# Altium schematic sheet Power Supply und DC-DC Converter.SchDoc
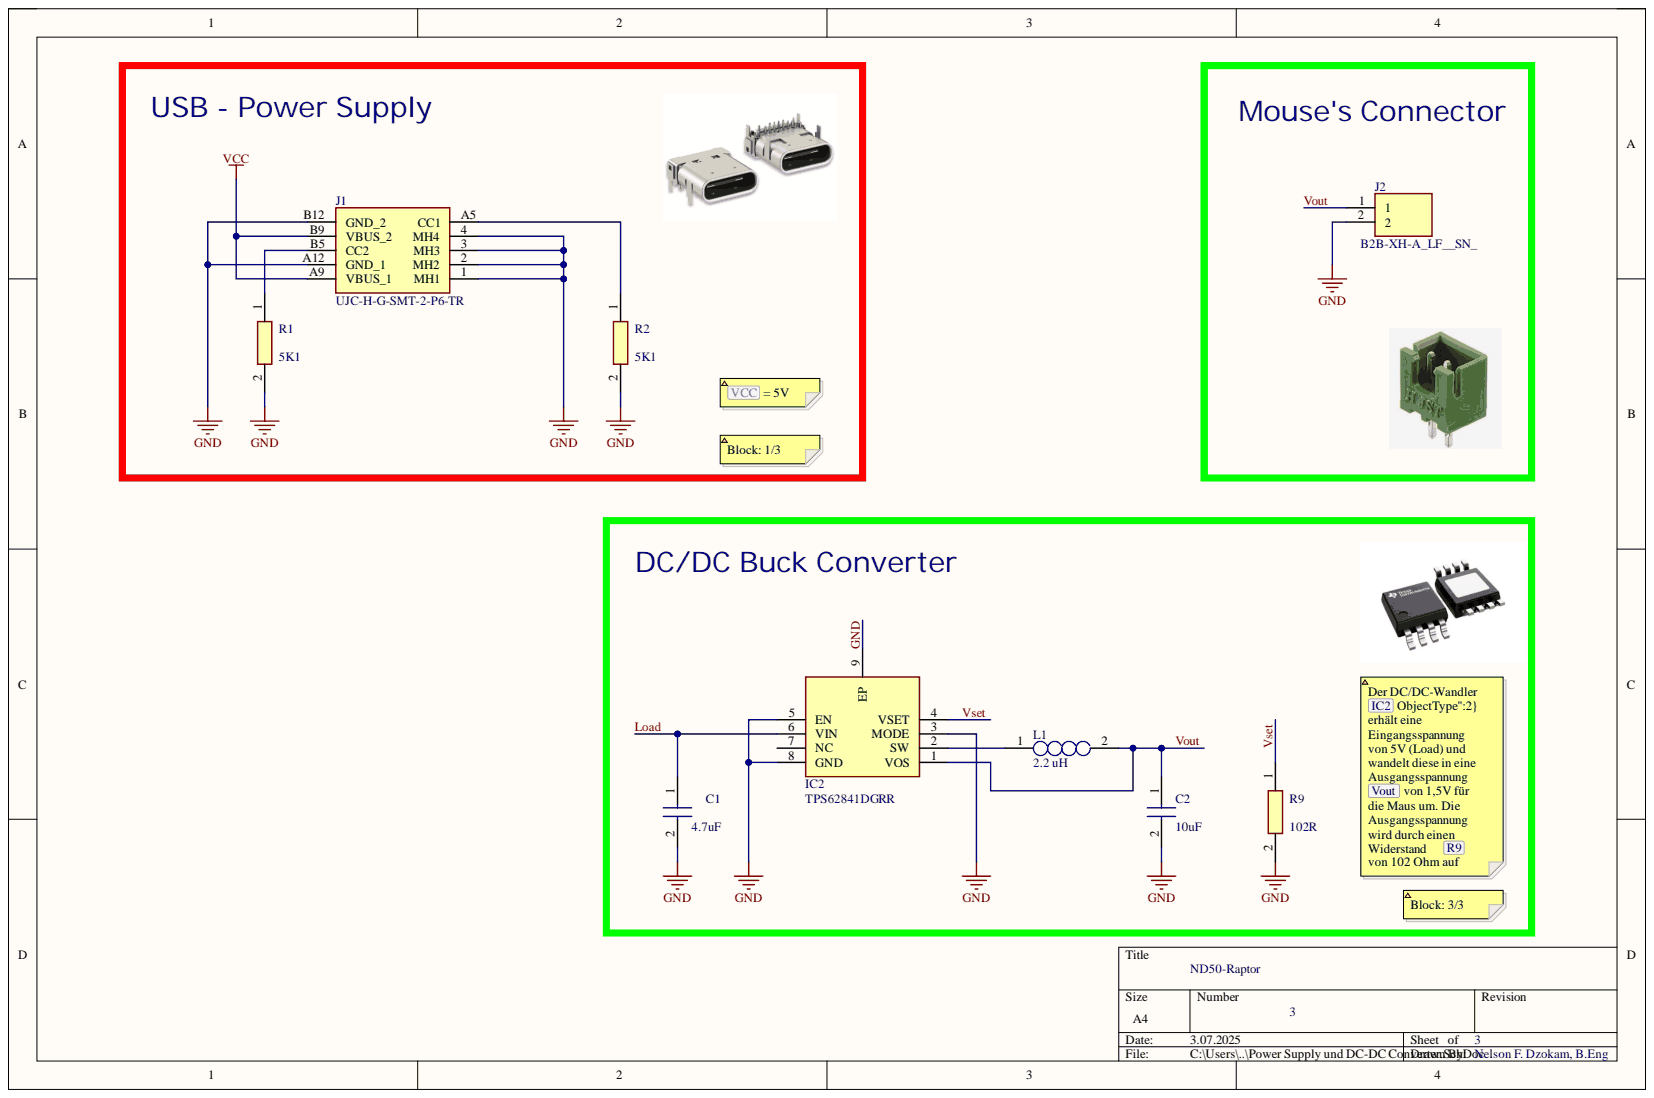
[diagram p0: Power Supply und DC-DC Converter.SchDoc]

## Parts
C1  4.7uF  [CAPC2012X145N]
C2  10uF  [C0805]
IC2  TPS62841DGRR  [SOP65P490X110-9N]
J1  UJC-H-G-SMT-2-P6-TR  [UJCHGSMT2P6TR]
J2  B2B-XH-A_LF__SN_  [SHDR2W64P0X250_1X2_740X575X700P]
L1  2.2 uH  [1286ASH1R0MP2]
R1  5K1  [RESC2012X60N]
R2  5K1  [RESC2012X60N]
R9  102R  [ERJ6ENF1000V]

## Nets
VCC: J1.A9(VBUS_1) J1.B9(VBUS_2)
GND: C1.2 C2.2 IC2.3(MODE) IC2.5(EN) IC2.8(GND) IC2.9(EP) J1.1(MH1) J1.2(MH2) J1.3(MH3) J1.4(MH4) J1.A12(GND_1) J1.B12(GND_2) J2.2 R1.2 R2.2 R9.2
Load: C1.1 IC2.6(VIN)
Vout: C2.1 IC2.1(VOS) J2.1 L1.2
Vset: IC2.4(VSET) R9.1
NetIC2_2: IC2.2(SW) L1.1
NetJ1_A5: J1.A5(CC1) R2.1
NetJ1_B5: J1.B5(CC2) R1.1

Not connected: IC2 7(NC)

## Library data of the parts
C1 = 885012107009: Capacitor               885012107009; Manufacturer_Name: Wurth Elektronik; Component Kind: Standard; Datasheet Link: https://katalog.we-online.com/pbs/datasheet/885012107009.pdf; Height: 1.45mm; Manufacturer_Part_Number: 885012107009; Mouser Part Number: 710-885012107009; Mouser Price/Stock: https://www.mouser.com/Search/Refine.aspx?Keyword=710-885012107009
C2 = C0805C106K7PACTU: Capacitor  C0805C106K7PACTU; Manufacturer_Name: KEMET; Component Kind: Standard; Datasheet Link: https://content.kemet.com/datasheets/KEM_C1006_X5R_SMD.pdf; Height: 1.1mm; Manufacturer_Part_Number: C0805C106K7PACTU; Mouser Part Number: 80-C0805C106K7P; Mouser Price/Stock: https://www.mouser.com/Search/Refine.aspx?Keyword=80-C0805C106K7P
IC2 = TPS62841DGRR: Integrated Circuit; Manufacturer_Name: Texas Instruments; Arrow Part Number: TPS62841DGRR; Arrow Price/Stock: https://www.arrow.com/en/products/tps62841dgrr/texas-instruments?region=nac; Component Kind: Standard; Datasheet Link: https://www.ti.com/lit/ds/symlink/tps62840.pdf?ts=1616629326125&ref_url=https%253A%252F%252Fwww.ti.com%252Fproduct%252FTPS62840; Height: 1.1mm; Manufacturer_Part_Number: TPS62841DGRR; Mouser Part Number: 595-TPS62841DGRR; Mouser Price/Stock: https://www.mouser.co.uk/ProductDetail/Texas-Instruments/TPS62841DGRR?qs=7MVldsJ5UaxD6apCWXKy1A%3D%3D
J1 = UJC-H-G-SMT-2-P6-TR: Connector; Manufacturer_Name: Same Sky; Component Kind: Standard; Datasheet Link: https://www.sameskydevices.com/product/resource/ujc-h-g-smt-2-p6-tr.pdf; Height: 3.36mm; Manufacturer_Part_Number: UJC-H-G-SMT-2-P6-TR; Mouser Part Number: 179-UJCHGSMT2P6TR; Mouser Price/Stock: https://www.mouser.co.uk/ProductDetail/Same-Sky/UJC-H-G-SMT-2-P6-TR?qs=IKkN%2F947nfApFV8T6rOqww%3D%3D
J2 = B2B-XH-A_LF__SN_: Connector                         B2B-XH-A_LF__SN_; Manufacturer_Name: JST (JAPAN SOLDERLESS TERMINALS); Manufacturer_Part_Number: B2B-XH-A(LF)(SN); Arrow Part Number: B2B-XH-A(LF)(SN); Arrow Price/Stock: https://www.arrow.com/en/products/b2b-xh-a-lf-sn/jst-manufacturing?utm_currency=USD&region=europe; Component Kind: Standard; Datasheet Link: http://www.jst-mfg.com/product/pdf/eng/eXH.pdf; Height: 7mm; Mouser Part Number: 306-B2BXHALFSNP; Mouser Price/Stock: https://www.mouser.co.uk/ProductDetail/JST-Commercial/B2B-XH-ALFSN?qs=cdbOS8ANM9DdcSn9qRmfCw%3D%3D
L1 = DFE201612E-2R2M=P2: Inductor  DFE201612E-2R2M=P2; Manufacturer_Name: Murata Electronics; Arrow Part Number: DFE201612E-2R2M=P2; Arrow Price/Stock: https://www.arrow.com/en/products/dfe201612e-2r2mp2/murata-manufacturing?region=nac; Component Kind: Standard; Datasheet Link: https://search.murata.co.jp/Ceramy/image/img/P02/J(E)TE243A-0006.pdf; Height: 1.2mm; Manufacturer_Part_Number: DFE201612E-2R2M=P2; Mouser Part Number: 81-DFE201612E-2R2MP2; Mouser Price/Stock: https://www.mouser.co.uk/ProductDetail/Murata-Electronics/DFE201612E-2R2M%3dP2?qs=AQlKX63v8Rsi4vow61OBVg%3D%3D
R1 R2 = CRCW08055K10FKEAC: Resistor  CRCW08055K10FKEAC; Manufacturer_Name: Vishay; Arrow Part Number: CRCW08055K10FKEAC; Arrow Price/Stock: https://www.arrow.com/en/products/crcw08055k10fkeac/vishay?region=nac; Component Kind: Standard; Datasheet Link: https://mouser.componentsearchengine.com/Datasheets/1/CRCW08055K10FKEAC.pdf; Height: 0.6mm; Manufacturer_Part_Number: CRCW08055K10FKEAC; Mouser Part Number: 71-CRCW08055K10FKEAC; Mouser Price/Stock: https://www.mouser.co.uk/ProductDetail/Vishay-Dale/CRCW08055K10FKEAC?qs=E3Y5ESvWgWNkJu%252BSzwruKw%3D%3D
R9 = ERJ-6ENF1020V: Resistor                ERJ-6ENF1020V; Manufacturer_Name: Panasonic; Component Kind: Standard; Datasheet Link: https://industrial.panasonic.com/cdbs/www-data/pdf/RDA0000/AOA0000C84.pdf; Height: 0.7mm; Manufacturer_Part_Number: ERJ-6ENF1020V; Mouser Part Number: 667-ERJ-6ENF1020V; Mouser Price/Stock: https://www.mouser.com/Search/Refine.aspx?Keyword=667-ERJ-6ENF1020V
